# Altium schematic sheet Top_Level.SchDoc(Top_Level)
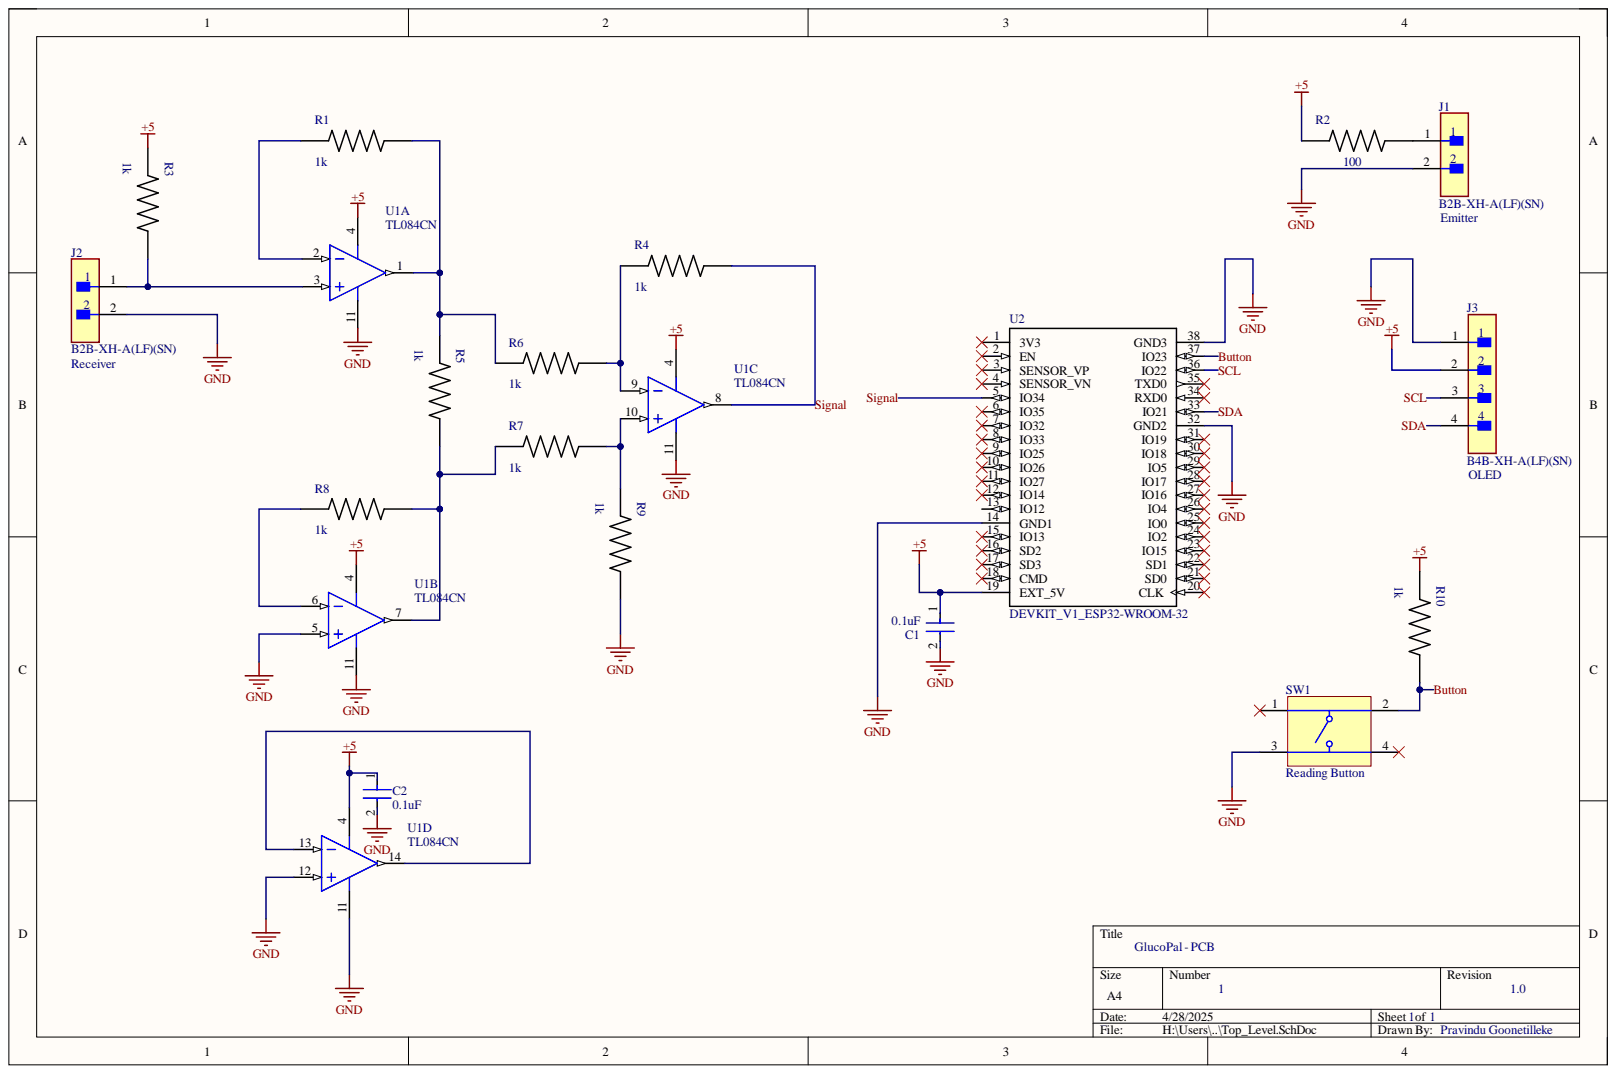
[diagram p0: Top_Level.SchDoc]

## Parts
C1  0.1uF  [FP-K15H5-MFG]
C2  0.1uF  [FP-K15H5-MFG]
J1  B2B-XH-A(LF)(SN)  [FP-B2B-XH-A_LF_SN-MFG]
J2  B2B-XH-A(LF)(SN)  [FP-B2B-XH-A_LF_SN-MFG]
J3  B4B-XH-A(LF)(SN)  [FP-B4B-XH-A_LF_SN-MFG]
R1  1k  [*RESAD1520W70L1030D380]
R2  100  [*RESAD1520W70L1030D380]
R3  1k  [*RESAD1520W70L1030D380]
R4  1k  [*RESAD1520W70L1030D380]
R5  1k  [*RESAD1520W70L1030D380]
R6  1k  [*RESAD1520W70L1030D380]
R7  1k  [*RESAD1520W70L1030D380]
R8  1k  [*RESAD1520W70L1030D380]
R9  1k  [*RESAD1520W70L1030D380]
R10  1k  [*RESAD1520W70L1030D380]
SW1  Reading Button  [TECO-1825910-6_V]
U1  TL084CN  [N0014A]  (parts A,B,C,D)
U2  DEVKIT_V1_ESP32-WROOM-32  [*MODULE_DEVKIT_V1_ESP32-WROOM-32]

## Nets
+5: C1.1 C2.1 J3.2 R2.1 R3.1 R10.1 U1.4 U2.19(EXT_5V)
GND: C1.2 C2.2 J1.2 J2.2 J3.1 R9.2 SW1.3 U1.5 U1.11 U1.12 U2.14(GND1) U2.32(GND2) U2.38(GND3)
Button: R10.2 SW1.2 U2.37(IO23)
SCL: J3.3 U2.36(IO22)
SDA: J3.4 U2.33(IO21)
Signal: R4.2 U1.8 U2.5(IO34)
NetJ1_1: J1.1 R2.2
NetJ2_1: J2.1 R3.2 U1.3
NetR1_1: R1.1 U1.2
NetR1_2: R1.2 R5.1 R6.1 U1.1
NetR4_1: R4.1 R6.2 U1.9
NetR5_2: R5.2 R7.1 R8.2 U1.7
NetR7_2: R7.2 R9.1 U1.10
NetR8_1: R8.1 U1.6
NetU1_13: U1.13 U1.14

Not connected: SW1 1 4; U2 1(3V3) 2(EN) 3(SENSOR_VP) 4(SENSOR_VN) 6(IO35) 7(IO32) 8(IO33) 9(IO25) 10(IO26) 11(IO27) 12(IO14) 13(IO12) 15(IO13) 16(SD2) 17(SD3) 18(CMD) 20(CLK) 21(SD0) 22(SD1) 23(IO15) 24(IO2) 25(IO0) 26(IO4) 27(IO16) 28(IO17) 29(IO5) 30(IO18) 31(IO19) 34(RXD0) 35(TXD0)

## Library data of the parts
C1 C2 = CMP-02417-000078-1: CAP CER 0.1UF 50V X7R RADIAL; Capacitance: 100nF; Dielectric Material: Ceramic; Lead Diameter: 0.5mm; Lead Length: 30mm; Lead/Base Style: Formed Leads; Tolerance: 10%; Case/Package: Dipped; Component Kind: Standard; Construction: RADIAL; Depth: 2.5mm; Diameter: 500um; Dielectric: X7R; Height: 4mm; Height - Seated (Max): 0.257inch; Lead Free: Lead Free; Lead Pitch: 5mm; Length: 4mm; Library Name: Altium Content Vault; Max Operating Temperature: 125°C; Min Operating Temperature: -55°C; Mount: Through Hole; Package Quantity: 1; Packaging: Tape and Reel; Radiation Hardening: No; RoHS Compliant: Yes; Series: K; Termination: Radial; Thickness: 2.6mm; Voltage: 50V; Voltage Rating: 50V; Voltage Rating (DC): 50V; Weight: 0.005644oz; Width: 2.5mm
J1 J2 = CMP-2000-05888-3: CONN HEADER VERT 2POS 2.5MM; Connector Type: Male Pin; Contact Resistance: 20mR; Current Rating: 3A; Insulation Resistance: 1GR; Max Voltage Rating (AC): 250V; Number of Contacts: 2; Wire/Cable Gauge: 22AWG; Color: Natural; Component Kind: Standard; Contact Material: Brass; Contact Plating: Tin; Contact Type: Male Pin; Depth: 5.75mm; Fastening Type: Detent; Housing Color: White; Housing Material: Polyamide; Lead Free: Lead Free; Lead Pitch: 2.5mm; Length: 7.4mm; Library Name: Altium Content Vault; Max Current Rating: 3A; Max Operating Temperature: 85°C; Max Voltage Rating (DC): 250V; Min Operating Temperature: -25°C; Mount: Through Hole; Number of Positions: 2; Number of Rows: 1; Orientation: Straight; Packaging: Bulk; Pitch: 2.5mm; REACH SVHC: No SVHC; Series: XH; Termination: Solder; Voltage Rating: 250V; Voltage Rating (AC): 250V
J3 = CMP-17439-000250-1: CONN HEADER VERT 4POS 2.5MM; Contact Resistance: 20mR; Current Rating: 3A; Gender: Male; Insulation Resistance: 1GR; Mated Stacking Heights: 9.8mm; Max Voltage Rating (AC): 250V; Number of Contacts: 4; Wire/Cable Gauge: 22AWG; Color: Natural; Component Kind: Standard; Connector Type: Header; Contact Finish - Mating: TIN; Contact Material: Brass; Contact Plating: Tin; Contact Shape: Square; Contact Type: Male Pin; Depth: 5.75mm; Fastening Type: Detent; Flammability Rating: UL94 V-0; Housing Color: White; Housing Material: Polyamide; Insulation Color: Natural; Insulation Material: Nylon; Lead Free: Lead Free; Lead Pitch: 2.5mm; Length: 12.4mm; Library Name: Altium Content Vault; Material: Polyamide; Max Current Rating: 3A; Max Operating Temperature: 85°C; Max Voltage Rating (DC): 250V; Min Operating Temperature: -25°C; Mount: Through Hole; Number of Positions: 4; Number of Rows: 1; Orientation: Straight; Package Quantity: 500; Packaging: Bulk; Pitch: 2.5mm; REACH SVHC: No SVHC; Series: XH; Termination: Solder; Voltage Rating: 250V; Voltage Rating (AC): 250V
R1-R10 = CBT50J5R1: 5.1 Ohms Â±5% 0.5W, 1/2W Through Hole Resistor Axial Pulse Withstanding Carbon Composition; MF: TE Connectivity / AMP; Availability: In Stock; Check_prices: https://www.snapeda.com/parts/CBT50J5R1/TE+Connectivity+%252F+AMP/view-part/?ref=eda; Component Kind: Standard; Library Name: CBT50J5R1.IntLib; MP: CBT50J5R1; Package: Axial TE Connectivity / AMP; Price: None; SnapEDA_Link: https://www.snapeda.com/parts/CBT50J5R1/TE+Connectivity+%252F+AMP/view-part/?ref=snap
SW1 = CMP-1684-00021-1: Tact Switch, SPST-NO, 0.05 A, -35 to 85 degC, 4-Pin THD, RoHS, Bulk; Manufacturer: TE Connectivity; Part No: 1825910-6; Actuator Color: Black; Actuator Length: 1.4mm; Actuator Material: PolybutyleneTerephthalate; Actuator Style: RoundButton; Body Orientation: Straight; Bushing Type: Unthreaded; Contact Rating @ Voltage: 0.05A@24VDC; Dielectric Strength: 500VAC; Electrical Life: 100000Cycles; Function: MomentaryContact; Illumination: N/R; Maximum Contact Resistance: 100mOhm; Maximum DC Voltage Rating: 24V; Pole Throw Configuration: SPST; Switch Configuration: OFF(ON); Base Material: N/A; Component Kind: Standard; Contact Material: CopperAlloy; Contact Plating: Silver; Datasheet URL: http://www.te.com/commerce/DocumentDelivery/DDEController?Action=showdoc&DocId=Customer+Drawing%7F1825910%7FC9%7Fpdf%7FEnglish%7FENG_CD_1825910_C9.pdf%7F1825910-6; Datasheet Version: Rev. C9; Dimension: 5.99x5mm; Family: 1825910; Housing Material: N/A; Library Name: Altium Content Vault; Manufacturer URL: http://www.te.com; Maximum AC Voltage Rating: N/A; Mechanical Life: 100000Cycles; Minimum Insulation Resistance: 100MOhm; Mounting: ThroughHole; Operating Temperature: -35to85C; Package Description: 4-Pin Through Hole Device, Body 5.99 x 5.99 mm, Pitch 6.5 mm; Package Reference: THD; Packaging: N/A; Product Weight: N/A; RoHS: Yes; Seal Description: N/A; Seal Location: N/A; Terminal Type: PCPins; Thread Size: N/R
U1 = CMP-0017-00818-2: JFET-Input Operational Amplifier, 7 to 36 V, 0 to 70 degC, 14-pin DIP (N14), Pb-Free (RoHS); Manufacturer: Texas Instruments; CMRR(Min)(dB): 70; GBW(Typ)(MHz): 3; IIB(Max)(pA): 400; Iq per channel(Max)(mA): 2.8; Number of Channels: 4; Offset Drift(Typ)(uV/C): 18; Rating: Catalog; Slew Rate(Typ)(V/us): 13; Sub Family: General Purpose Amplifier, High Supply Voltage Amplifier (>=30V); Total Supply Voltage(Max)(+5V is 5, +/-5V is 10): 36; Total Supply Voltage(Min)(+5V is 5, +/-5V is 10): 7; Vio (25C)(Max)(mV): 15; Code_JEDEC: MS-001; Component Kind: Standard; ComponentLink1Description: Manufacturer URL; ComponentLink1URL: http://www.ti.com/; ComponentLink2Description: Datasheet; ComponentLink2URL: http://www.ti.com/lit/ds/symlink/tl084.pdf; ComponentLink3Description: Package Specification; ComponentLink3URL: http://www.ti.com/lit/ml/mpdi002c/mpdi002c.pdf; DatasheetVersion: SLOS081G; Eco-Plan: Pb-Free (RoHS); Library Name: Altium Content Vault; Mounting Technology: Through Hole; PackageDescription: 14-Pin Plastic In-Line, Body 19.305 x 6.35 mm, Pitch 2.54 mm; PackageReference: N0014A; PackageVersion: 4040049/E, 12/2002; PartNumber: TL084CN; Vn at 1kHz(Typ)(nV/rtHz): 18
U2 = DEVKIT_V1_ESP32-WROOM-32: WROOM-32 Development Board ESP32 ESP-32S WiFi Bluetooth Dev Module; MF: Espressif Systems; MP: DEVKIT V1 ESP32-WROOM-32; Availability: Not in stock; Check_prices: https://www.snapeda.com/parts/DEVKIT%20V1%20ESP32-WROOM-32/Espressif+Systems/view-part/?ref=eda; Component Kind: Standard; Library Name: DEVKIT_V1_ESP32-WROOM-32.IntLib; Package: Package; Price: None; SnapEDA_Link: https://www.snapeda.com/parts/DEVKIT%20V1%20ESP32-WROOM-32/Espressif+Systems/view-part/?ref=snap
